# Altium schematic sheet Mother_board_SSL2018.SchDoc
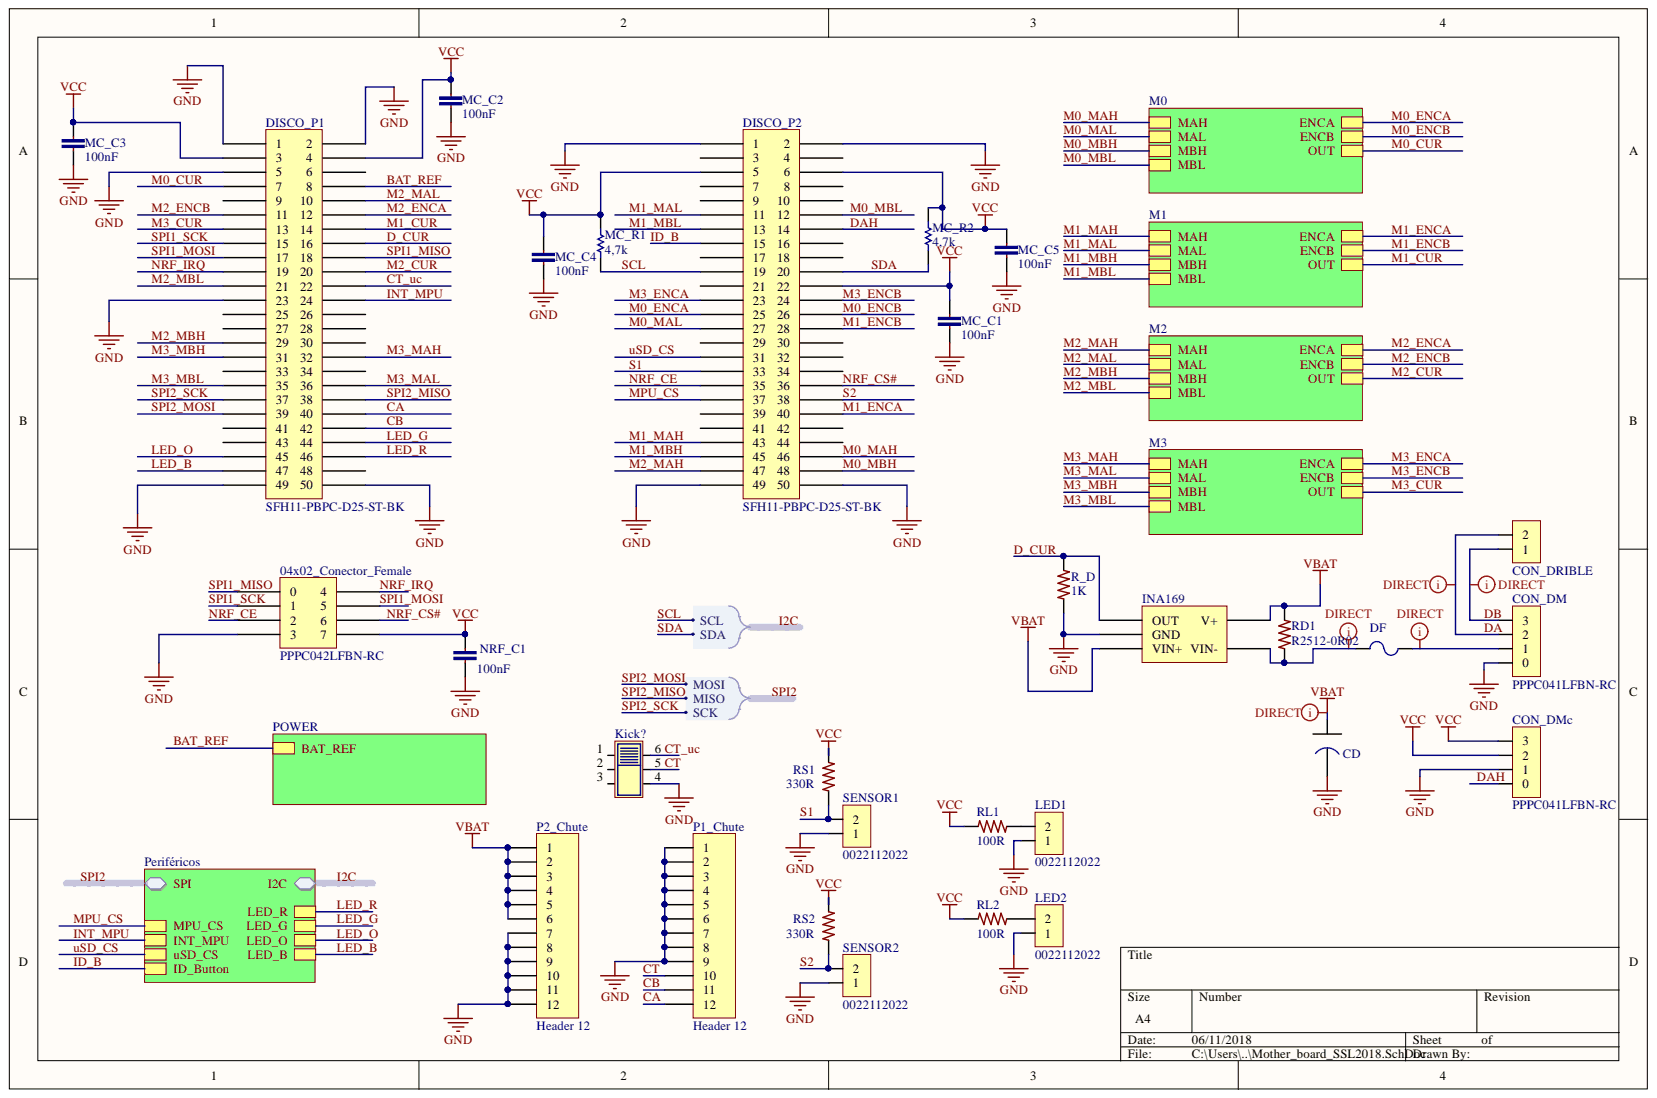
[diagram p0: Mother_board_SSL2018.SchDoc]

## Parts
04x02_Conector_Female  PPPC042LFBN-RC  [04x02_Conector_Female]
CD  EEE-FK1C681GP  [EEE-FK1C681GP]  (value not drawn)
CON_DM  PPPC041LFBN-RC  [04x01_Conector_Female - duplicate]
CON_DMc  PPPC041LFBN-RC  [04x01_Conector_Female - duplicate]
CON_DRIBLE  0022112022  [02x01_Conector_Male]
DF  MF-MSMF250/16X  [RESC4632X16N]  (value not drawn)
DISCO_P1  SFH11-PBPC-D25-ST-BK  [25x02_Conector_Female]
DISCO_P2  SFH11-PBPC-D25-ST-BK  [25x02_Conector_Female]
INA169  INA169NA  [SOT95P280X125-5N]  (value not drawn)
Kick?  JS202011SCQN  [SOP250P750X350-6N]  (value not drawn)
LED1  0022112022  [02x01_Conector_Male]
LED2  0022112022  [02x01_Conector_Male]
MC_C1  100nF  [0402-CAP]
MC_C2  100nF  [0402-CAP]
MC_C3  100nF  [0402-CAP]
MC_C4  100nF  [0402-CAP]
MC_C5  100nF  [0402-CAP]
MC_R1  4,7k  [0402]
MC_R2  4,7k  [0402]
NRF_C1  100nF  [0402-CAP]
P1_Chute  Header 12  [HDR1X12]
P2_Chute  Header 12  [HDR1X12]
R_D  1K  [0402-RES-HD]
RD1  R2512-0R02  [2512-RES-HD]
RL1  100R  [0402-RES-HD]
RL2  100R  [0402-RES-HD]
RS1  330R  [0402-RES-HD]
RS2  330R  [0402-RES-HD]
SENSOR1  0022112022  [02x01_Conector_Male]
SENSOR2  0022112022  [02x01_Conector_Male]

## Nets
GND: 04x02_Conector_Female.3 CD.2 CON_DM.0 CON_DMc.1 DISCO_P1.1 DISCO_P1.2 DISCO_P1.5 DISCO_P1.23 DISCO_P1.49 DISCO_P1.50 DISCO_P2.1 DISCO_P2.2 DISCO_P2.49 DISCO_P2.50 INA169.2(GND) Kick?.4 LED1.1 LED2.1 MC_C1.1 MC_C2.1 MC_C3.2 MC_C4.1 MC_C5.1 NRF_C1.2 P1_Chute.1 P1_Chute.2 P1_Chute.3 P1_Chute.4 P1_Chute.5 P1_Chute.6 P1_Chute.7 P1_Chute.8 P1_Chute.9 P2_Chute.7 P2_Chute.8 P2_Chute.9 P2_Chute.10 P2_Chute.11 P2_Chute.12 R_D.1 SENSOR1.1 SENSOR2.1
VBAT: CD.1 INA169.3(VIN+) INA169.4(V+) P2_Chute.1 P2_Chute.2 P2_Chute.3 P2_Chute.4 P2_Chute.5 P2_Chute.6 RD1.2
VCC: 04x02_Conector_Female.7 CON_DMc.2 CON_DMc.3 DISCO_P1.3 DISCO_P1.4 DISCO_P2.5 DISCO_P2.6 DISCO_P2.22 MC_C1.2 MC_C2.2 MC_C3.1 MC_C4.2 MC_C5.2 MC_R1.2 MC_R2.2 NRF_C1.1 RL1.1 RL2.1 RS1.2 RS2.2
BAT_REF: DISCO_P1.8
CA: DISCO_P1.40 P1_Chute.12
CB: DISCO_P1.42 P1_Chute.11
CT: Kick?.5 P1_Chute.10
CT_uc: DISCO_P1.22 Kick?.6
D_CUR: DISCO_P1.16 INA169.1(OUT) R_D.2
DA: CON_DM.2 CON_DRIBLE.2
DAH: CON_DMc.0 DISCO_P2.14
DB: CON_DM.3 CON_DRIBLE.1
I2C.SCL: DISCO_P2.19 MC_R1.1
I2C.SDA: DISCO_P2.20 MC_R2.1
ID_B: DISCO_P2.15
INT_MPU: DISCO_P1.24
LED_B: DISCO_P1.47
LED_G: DISCO_P1.44
LED_O: DISCO_P1.45
LED_R: DISCO_P1.46
M0_CUR: DISCO_P1.7
M0_ENCA: DISCO_P2.25
M0_ENCB: DISCO_P2.26
M0_MAH: DISCO_P2.46
M0_MAL: DISCO_P2.27
M0_MBH: DISCO_P2.48
M0_MBL: DISCO_P2.12
M1_CUR: DISCO_P1.14
M1_ENCA: DISCO_P2.40
M1_ENCB: DISCO_P2.28
M1_MAH: DISCO_P2.43
M1_MAL: DISCO_P2.11
M1_MBH: DISCO_P2.45
M1_MBL: DISCO_P2.13
M2_CUR: DISCO_P1.20
M2_ENCA: DISCO_P1.12
M2_ENCB: DISCO_P1.11
M2_MAH: DISCO_P2.47
M2_MAL: DISCO_P1.10
M2_MBH: DISCO_P1.29
M2_MBL: DISCO_P1.21
M3_CUR: DISCO_P1.13
M3_ENCA: DISCO_P2.23
M3_ENCB: DISCO_P2.24
M3_MAH: DISCO_P1.32
M3_MAL: DISCO_P1.36
M3_MBH: DISCO_P1.31
M3_MBL: DISCO_P1.35
MPU_CS: DISCO_P2.37
NRF_CE: 04x02_Conector_Female.2 DISCO_P2.35
NRF_CS#: 04x02_Conector_Female.6 DISCO_P2.36
NRF_IRQ: 04x02_Conector_Female.4 DISCO_P1.19
S1: DISCO_P2.33 RS1.1 SENSOR1.2
S2: DISCO_P2.38 RS2.1 SENSOR2.2
SPI1_MISO: 04x02_Conector_Female.0 DISCO_P1.18
SPI1_MOSI: 04x02_Conector_Female.5 DISCO_P1.17
SPI1_SCK: 04x02_Conector_Female.1 DISCO_P1.15
SPI2.MISO: DISCO_P1.38
SPI2.MOSI: DISCO_P1.39
SPI2.SCK: DISCO_P1.37
uSD_CS: DISCO_P2.31
NetCON_DM_1: CON_DM.1 DF.1
NetDF_2: DF.2 INA169.5(VIN-) RD1.1
NetLED1_2: LED1.2 RL1.2
NetLED2_2: LED2.2 RL2.2

Not connected: DISCO_P1 6 9 25 26 27 28 30 33 34 41 43 48; DISCO_P2 3 4 7 8 9 10 16 17 18 21 29 30 32 34 39 41 42 44; Kick? 1 2 3

## Library data of the parts
04x02_Conector_Female = 04x02_Conector_Female: 8 Position Header Connector 0.100" (2.54mm) Through Hole Gold; Component Kind: Standard; Library Name: Schlib1.SchLib
CD = CAP AL 680UF/16V: Aluminum Electrolytic Capacitors - SMD Al Lytic Cap SMT FK Series 105C; Component Kind: Standard; Library Name: Schlib1.SchLib
CON_DM CON_DMc = 04x01_Conector_Female-SCH: 4 Position Header Connector 0.100" (2.54mm) Through Hole Gold; Component Kind: Standard; Library Name: Schlib1.SchLib
CON_DRIBLE LED1 LED2 SENSOR1 SENSOR2 = 02x01_Conector_Male: CONN HEADER 2POS .100 VERT GOLD; Component Kind: Standard; Library Name: Schlib1.SchLib
DF = MF-MSMF250/16X-01.A.1: PTC RESETTABLE .30A 30V 1812; Component Kind: Standard; Library Name: IMBEL
DISCO_P1 DISCO_P2 = 25x02_Conector_Female: 50 Position Header Connector 0.100" (2.54mm) Through Hole Gold; Component Kind: Standard; Library Name: Schlib1.SchLib
INA169 = INA169NA-01.A.1: IC CURRENT MONITOR 0.5% SOT23-5; Component Kind: Standard; Library Name: IMBEL
Kick? = JS202011SCQN-1: SWITCH SLIDE DPDT 300MA 6V; Component Kind: Standard; Part No: JS202011SCQN; Library Name: IMBEL
MC_C1-MC_C5 NRF_C1 = CC0402-100nF-A.12: CAP CER 0.1UF 10V 20% X5R 0402; Part No: VJ0402V104ZXQPBC; Cod EMS: 05.281.006.133; Value: 100n; Component Kind: Standard; Simulation: Capacitor; Library Name: IMBEL
MC_R1 MC_R2 = Res1: Resistor; Component Kind: Standard; LatestRevisionDate: 17-Jul-2002; LatestRevisionNote: Re-released for DXP Platform.; Library Name: Miscellaneous Devices.IntLib; PackageDescription: Axial Device, Thru-Hole; 2 Leads; 0.3 in Pin Spacing; PackageReference: AXIAL-0.3; Published: 8-Jun-2000; Signal Integrity: Res; Simulation: RESISTOR; Value: 4,7K; Publisher: Altium Limited
P1_Chute P2_Chute = Header 12: Header, 12-Pin; Component Kind: Standard; LatestRevisionDate: 17-Jul-2002; LatestRevisionNote: Re-released for DXP Platform.; Library Name: Miscellaneous Connectors.IntLib; Signal Integrity: Connector; Publisher: Altium Limited
R_D = R0402-1K-A.9: RES 1K OHM 1/10W 1% 0402 SMD; Part No: ERJ-2RKF1001X; Cod EMS: 05.280.029.527; Family Description: RESISTOR FILME ESPESSO 10R-1M / 1/10W / 1% / 0402. P/N: ERJ-2RKF....X – PANASONIC ELECTRONIC COMPONENTS.; Component Kind: Standard; Family: ERJ-2RKF....X; Simulation: SimModel1; Value: 1K; Library Name: IMBEL
RD1 = R2512-0R02-4: RES .02 OHM 2W 1% 2512 SMD; Part No: WSL2512R0200FEA18; Cod EMS: 05.280.029.529; Family Description: RESISTOR SENTIDO DE CORRENTE 0,010R-0,040R/ 2W / 1% / 2512 P/N: WSL2512.....FEA18 – VISHAY DALE.; Value: 0.02; Component Kind: Standard; Family: WSL2512.....FEA18; Simulation: SimModel1; Library Name: IMBEL
RL1 RL2 = R0402-100R-5: RES 100 OHM 1/10W 1% 0402 SMD; Part No: ERJ-2RKF1000X; Cod EMS: 05.280.029.519; Family Description: RESISTOR FILME ESPESSO 10R-1M / 1/10W / 1% / 0402. P/N: ERJ-2RKF....X – PANASONIC ELECTRONIC COMPONENTS.; Value: 100; Component Kind: Standard; Family: ERJ-2RKF....X; Simulation: SimModel1; Library Name: IMBEL
RS1 RS2 = R0402-330R-7: RES 330 OHM 1/10W 1% 0402 SMD; Part No: ERJ-2RKF3300X; Cod EMS: 05.280.029.506; Family Description: RESISTOR FILME ESPESSO 10R-1M / 1/10W / 1% / 0402. P/N: ERJ-2RKF....X – PANASONIC ELECTRONIC COMPONENTS.; Value: 330; Component Kind: Standard; Family: ERJ-2RKF....X; Simulation: SimModel1; Library Name: IMBEL
